# Altium schematic sheet pwr.SchDoc
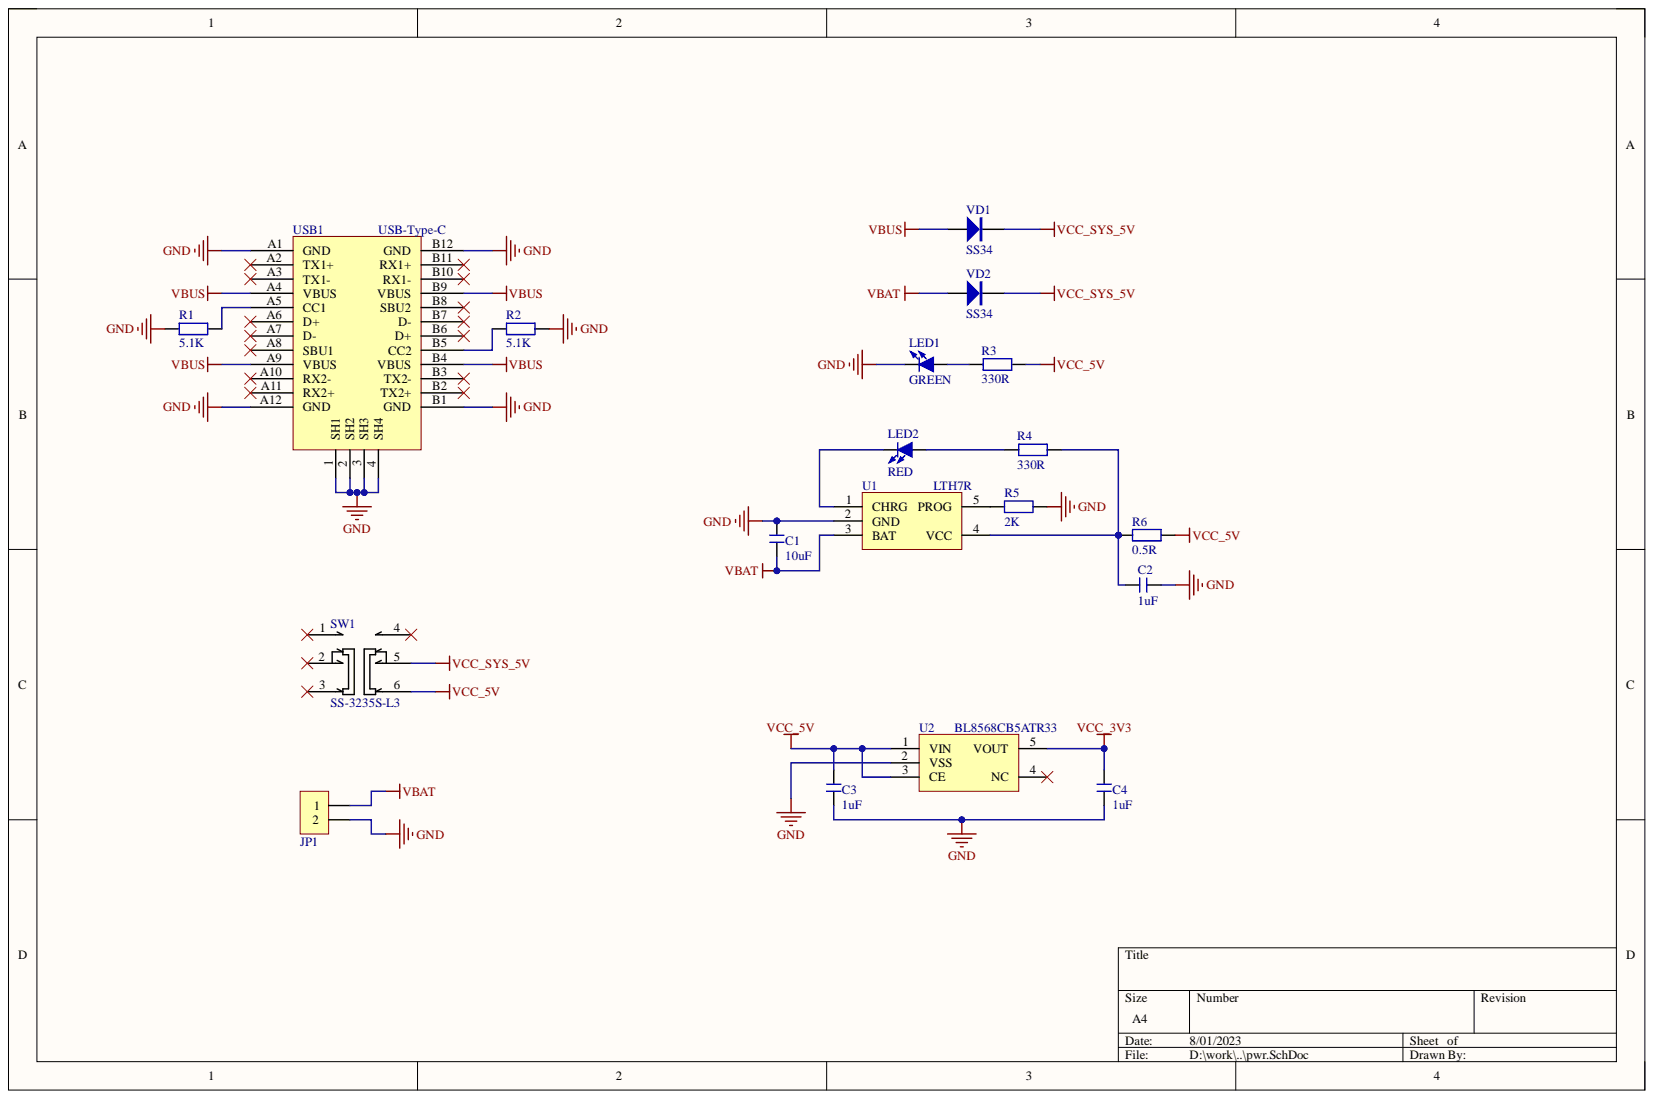
[diagram p0: pwr.SchDoc]

## Parts
C1  10uF  [C 0402]
C2  1uF  [C 0402]
C3  1uF  [C 0402]
C4  1uF  [C 0402]
JP1  HDR-1X2  [MX 1.25-WS-2P]  (value not drawn)
LED1  GREEN  [LED 0603G]
LED2  RED  [LED 0603R]
R1  5.1K  [R 0402]
R2  5.1K  [R 0402]
R3  330R  [R 0402]
R4  330R  [R 0402]
R5  2K  [R 0402]
R6  0.5R  [R 0603]
SW1  SS-3235S-L3  [SS-3235S-L3]
U1  LTH7R  [SOT23-5N]
U2  BL8568CB5ATR33  [SOT23-5N]
USB1  USB-Type-C  [Type-C-TOP]
VD1  SS34  [SMA]
VD2  SS34  [SMA]

## Nets
GND: C1.2 C2.2 C3.1 C4.1 JP1.2 LED1.2 R1.1 R2.2 R5.2 U1.2(GND) U2.2(VSS) USB1.1(SH1) USB1.2(SH2) USB1.3(SH3) USB1.4(SH4) USB1.A1(GND) USB1.A12(GND) USB1.B1(GND) USB1.B12(GND)
VBAT: C1.1 JP1.1 U1.3(BAT) VD2.1
VBUS: USB1.A4(VBUS) USB1.A9(VBUS) USB1.B4(VBUS) USB1.B9(VBUS) VD1.1
VCC_3V3: C4.2 U2.5(VOUT)
VCC_5V: C3.2 R3.1 R6.2 SW1.6 U2.1(VIN) U2.3(CE)
VCC_SYS_5V: SW1.5 VD1.2 VD2.2
NetC2_1: C2.1 R4.2 R6.1 U1.4(VCC)
NetLED1_1: LED1.1 R3.2
NetLED2_1: LED2.1 R4.1
NetLED2_2: LED2.2 U1.1(CHRG)
NetR1_2: R1.2 USB1.A5(CC1)
NetR2_1: R2.1 USB1.B5(CC2)
NetR5_1: R5.1 U1.5(PROG)

Not connected: SW1 1 2 3 4; U2 4(NC); USB1 A2(TX1+) A3(TX1-) A6(D+) A7(D-) A8(SBU1) A10(RX2-) A11(RX2+) B2(TX2+) B3(TX2-) B6(D+) B7(D-) B8(SBU2) B10(RX1-) B11(RX1+)

## Library data of the parts
C1-C4 = C: 无极性贴片电容; 版权归属: 源创客; BOM名称: 无极性贴片电容; Component Kind: Standard; Library Name: 电阻-电容-电感.SchLib; 学习资源: www.ooocke.com
JP1 = Header 2: 2P接插件; 技术支持，联系售后: 封装库来自淘宝卖家 ID：黄晶宝石; 版权归属: 封装库来自淘宝 ID：黄晶宝石; BOM名称: 2P接插件; Component Kind: Standard; Library Name: 5.0 - 接插件-脚距1.25.SchLib; 联系售后: https://shop463601444.taobao.com
LED1 LED2 = LED-SMD: 贴片LED; 版权归属: 源创客; BOM名称: 贴片LED; Component Kind: Standard; Library Name: LED.SchLib; 学习资源: www.ooocke.com
R1-R6 = R: 贴片电阻; 版权归属: 源创客; BOM名称: 贴片电阻; Component Kind: Standard; Library Name: 电阻-电容-电感.SchLib; 学习资源: www.ooocke.com
SW1 = SS-3235S-L3: W9.1xD3.55xH3.3 贴片6P拨动开关柄高4.0; LC: C381098; Component Kind: Standard; Library Name: Switch.SchLib
U1 = LTH7R: LTH7R Li Battery Power management; LC: C841234; Component Kind: Standard; Library Name: PowerManagerIC.SchLib
U2 = BL8568CB5ATR33: High PSRR 70dB@1kHz LDO Iout=500mA; LC: C243122; Component Kind: Standard; Library Name: PowerManagerIC.SchLib
VD1 VD2 = SS34: 肖特基二极管; 属性: 40V/3A; 版权归属: 源创客; BOM名称: 肖特基二极管; Component Kind: Standard; Library Name: 二极管-整流桥.SchLib; 学习资源: www.ooocke.com
USB1 = USB-Type-C: Component Kind: Standard; Library Name: Connector.SchLib
